# Altium schematic sheet MCU2_BD.SchDoc
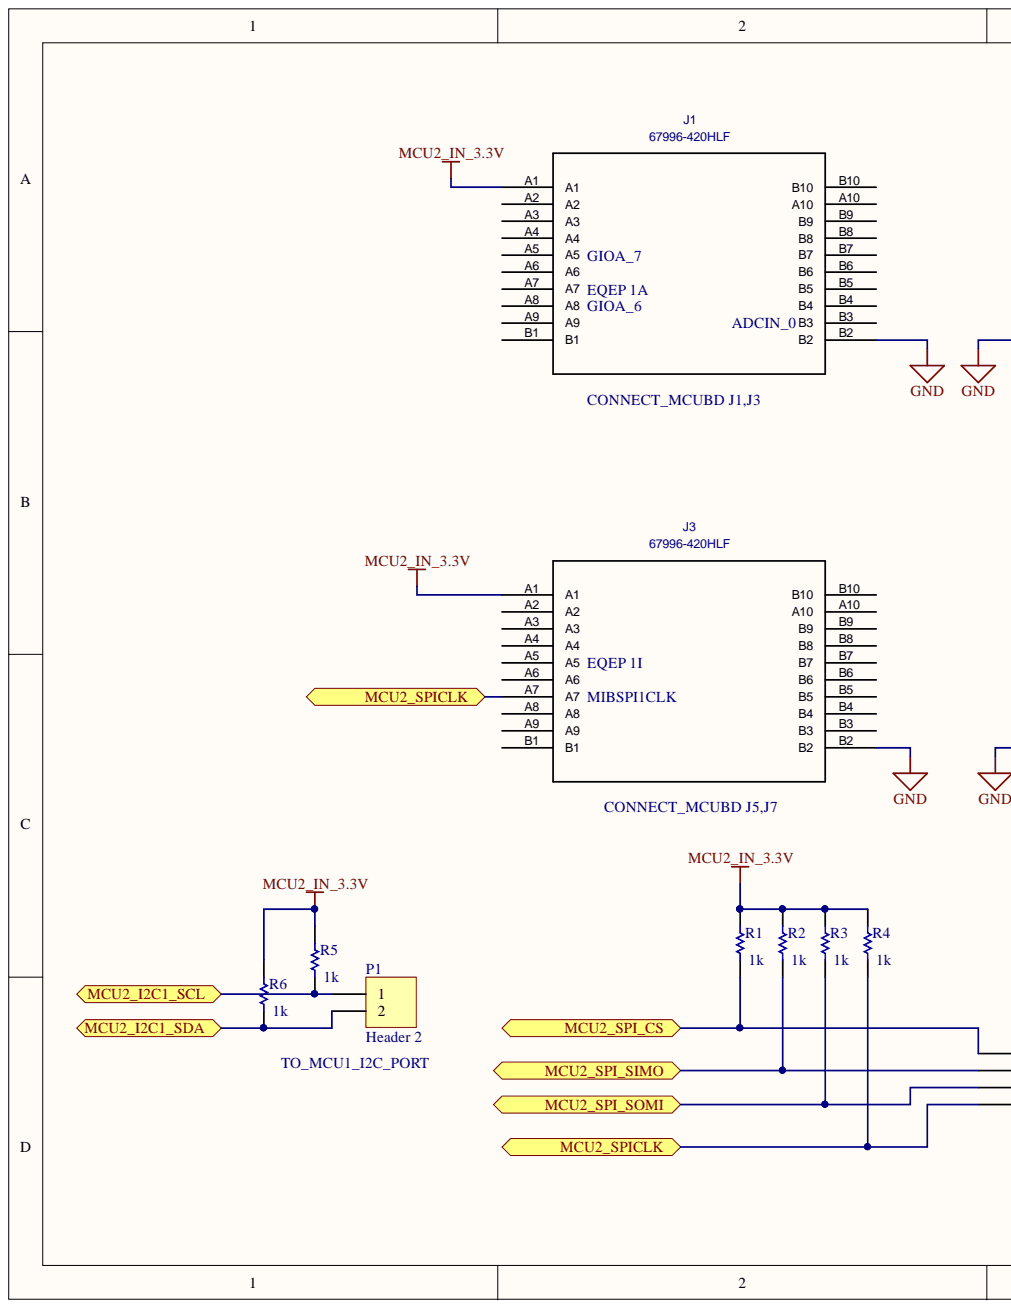
[diagram p0: MCU2_BD.SchDoc, left]
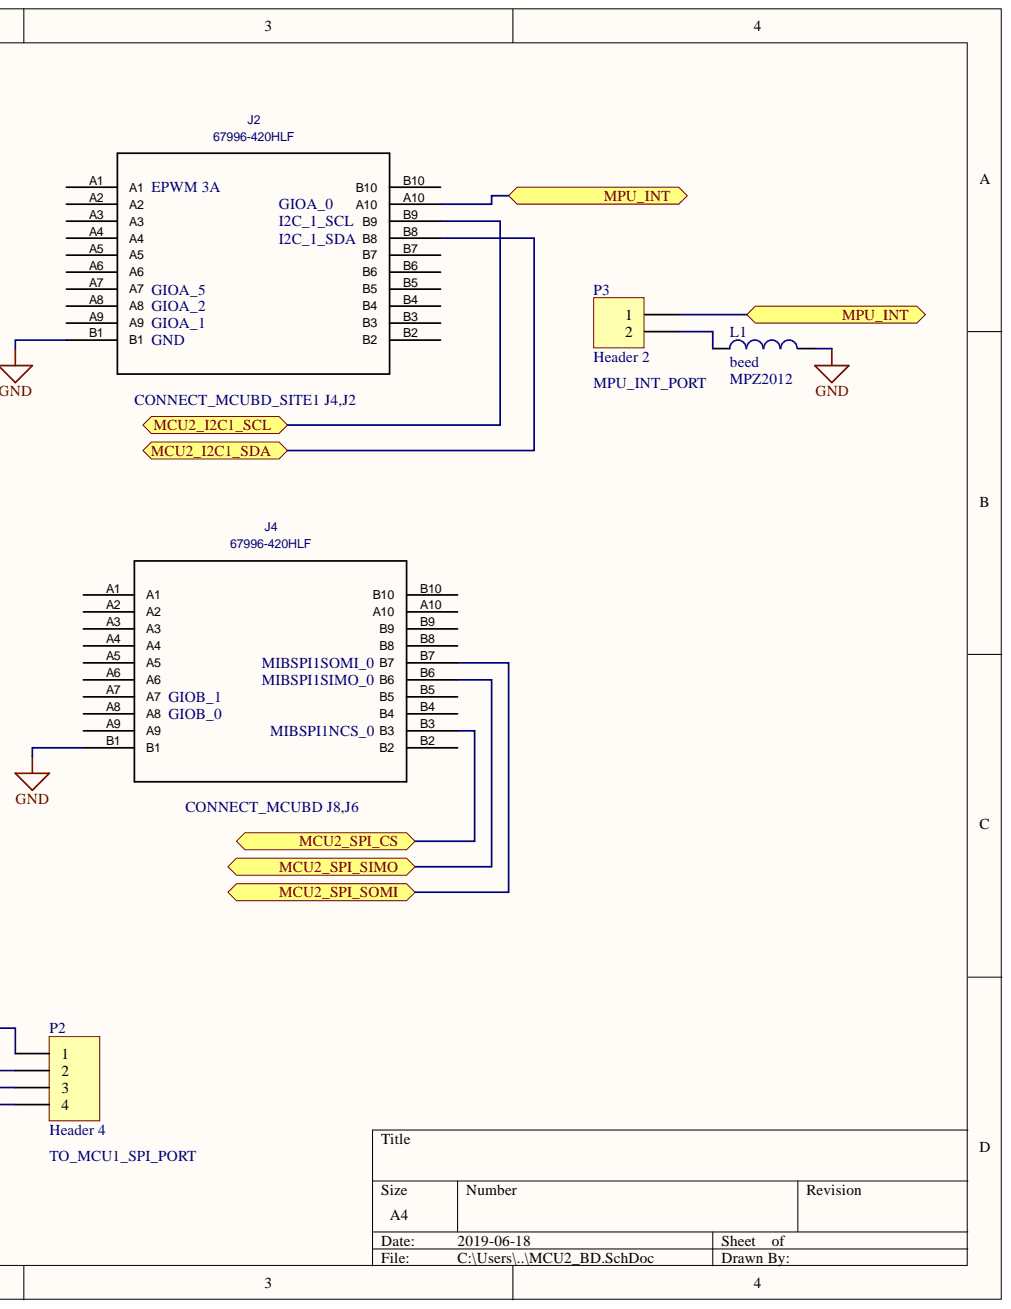
[diagram p1: MCU2_BD.SchDoc, right]

## Parts
J1  67996-420HLF  [67996-420HLF_67996-420HLF(Primary)]
J2  67996-420HLF  [67996-420HLF_67996-420HLF(Primary)]
J3  67996-420HLF  [67996-420HLF_67996-420HLF(Primary)]
J4  67996-420HLF  [67996-420HLF_67996-420HLF(Primary)]
L1  beed  value MPZ2012  [0402-A]
P1  Header 2  [HDR1X2]
P2  Header 4  [HDR1X4]
P3  Header 2  [HDR1X2]
R1  value 1k  [AXIAL-0.3]  (hidden comment: Res1)
R2  value 1k  [AXIAL-0.3]  (hidden comment: Res1)
R3  value 1k  [AXIAL-0.3]  (hidden comment: Res1)
R4  value 1k  [AXIAL-0.3]  (hidden comment: Res1)
R5  value 1k  [AXIAL-0.3]  (hidden comment: Res1)
R6  value 1k  [AXIAL-0.3]  (hidden comment: Res1)

## Ports
MCU2_I2C1_SCL, MCU2_I2C1_SDA, MCU2_SPI_CS, MCU2_SPI_SIMO, MCU2_SPI_SOMI, MCU2_SPICLK, MPU_INT

## Nets
GND: J1.B2 J2.B1 J3.B2 J4.B1 L1.2
MCU2_IN_3.3V: J1.A1 J3.A1 R1.2 R2.2 R3.2 R4.2 R5.2 R6.2
MCU2_I2C1_SCL: J2.B9 P1.1 R5.1 ; port MCU2_I2C1_SCL
MCU2_I2C1_SDA: J2.B8 P1.2 R6.1 ; port MCU2_I2C1_SDA
MCU2_SPI_CS: J4.B3 P2.1 R1.1 ; port MCU2_SPI_CS
MCU2_SPI_SIMO: J4.B6 P2.2 R2.1 ; port MCU2_SPI_SIMO
MCU2_SPI_SOMI: J4.B7 P2.3 R3.1 ; port MCU2_SPI_SOMI
MCU2_SPICLK: J3.A7 P2.4 R4.1 ; port MCU2_SPICLK
MPU_INT: J2.A10 P3.1 ; port MPU_INT
NetL1_1: L1.1 P3.2

Not connected: J1 A2 A3 A4 A5 A6 A7 A8 A9 A10 B1 B3 B4 B5 B6 B7 B8 B9 B10; J2 A1 A2 A3 A4 A5 A6 A7 A8 A9 B2 B3 B4 B5 B6 B7 B10; J3 A2 A3 A4 A5 A6 A8 A9 A10 B1 B3 B4 B5 B6 B7 B8 B9 B10; J4 A1 A2 A3 A4 A5 A6 A7 A8 A9 A10 B2 B4 B5 B8 B9 B10

## Library data of the parts
J1-J4 = 67996-420HLF: Built_By: EMA_UL_Team; C: Copyright (C) 2015 Accelerated Designs. All rights reserved; Vendor: Amphenol FCI; Component Kind: Standard; Library Name: 2X10pinM.SchLib; Manufacturer_Part_Number: 67996-420HLF; Value: 67996-420HLF
L1 = Inductor: Code_IEC: 1005; Code_JEITA: 0402; Component Kind: Standard; Description: Inductor; LatestRevisionDate: 29-May-2009; LatestRevisionNote: IPC-7351 Footprint Added.; Library Name: Miscellaneous Devices.IntLib; PackageDescription: Chip Inductor; PackageReference: 0402-A; Published: 8-Jun-2000; Signal Integrity: Inductor; Simulation: INDUCTOR; Publisher: Altium Limited
P1 P3 = Header 2: Header, 2-Pin; Component Kind: Standard; LatestRevisionDate: 17-Jul-2002; LatestRevisionNote: Re-released for DXP Platform.; Library Name: Miscellaneous Connectors.IntLib; Signal Integrity: Connector; Publisher: Altium Limited
P2 = Header 4: Header, 4-Pin; Component Kind: Standard; LatestRevisionDate: 17-Jul-2002; LatestRevisionNote: Re-released for DXP Platform.; Library Name: Miscellaneous Connectors.IntLib; Signal Integrity: Connector; Publisher: Altium Limited
R1-R4 R6 = Res1: Resistor; Component Kind: Standard; LatestRevisionDate: 17-Jul-2002; LatestRevisionNote: Re-released for DXP Platform.; Library Name: Miscellaneous Devices.IntLib; PackageDescription: Axial Device, Thru-Hole; 2 Leads; 0.3 in Pin Spacing; PackageReference: AXIAL-0.3; Published: 8-Jun-2000; Signal Integrity: Res; Simulation: RESISTOR; Publisher: Altium Limited
R5 = Res1: Resistor; Value: 1k; Component Kind: Standard; LatestRevisionDate: 17-Jul-2002; LatestRevisionNote: Re-released for DXP Platform.; Library Name: Miscellaneous Devices.IntLib; PackageDescription: Axial Device, Thru-Hole; 2 Leads; 0.3 in Pin Spacing; PackageReference: AXIAL-0.3; Published: 8-Jun-2000; Signal Integrity: Res; Simulation: RESISTOR; Publisher: Altium Limited
